# Altium schematic sheet Power_Supplies.SchDoc
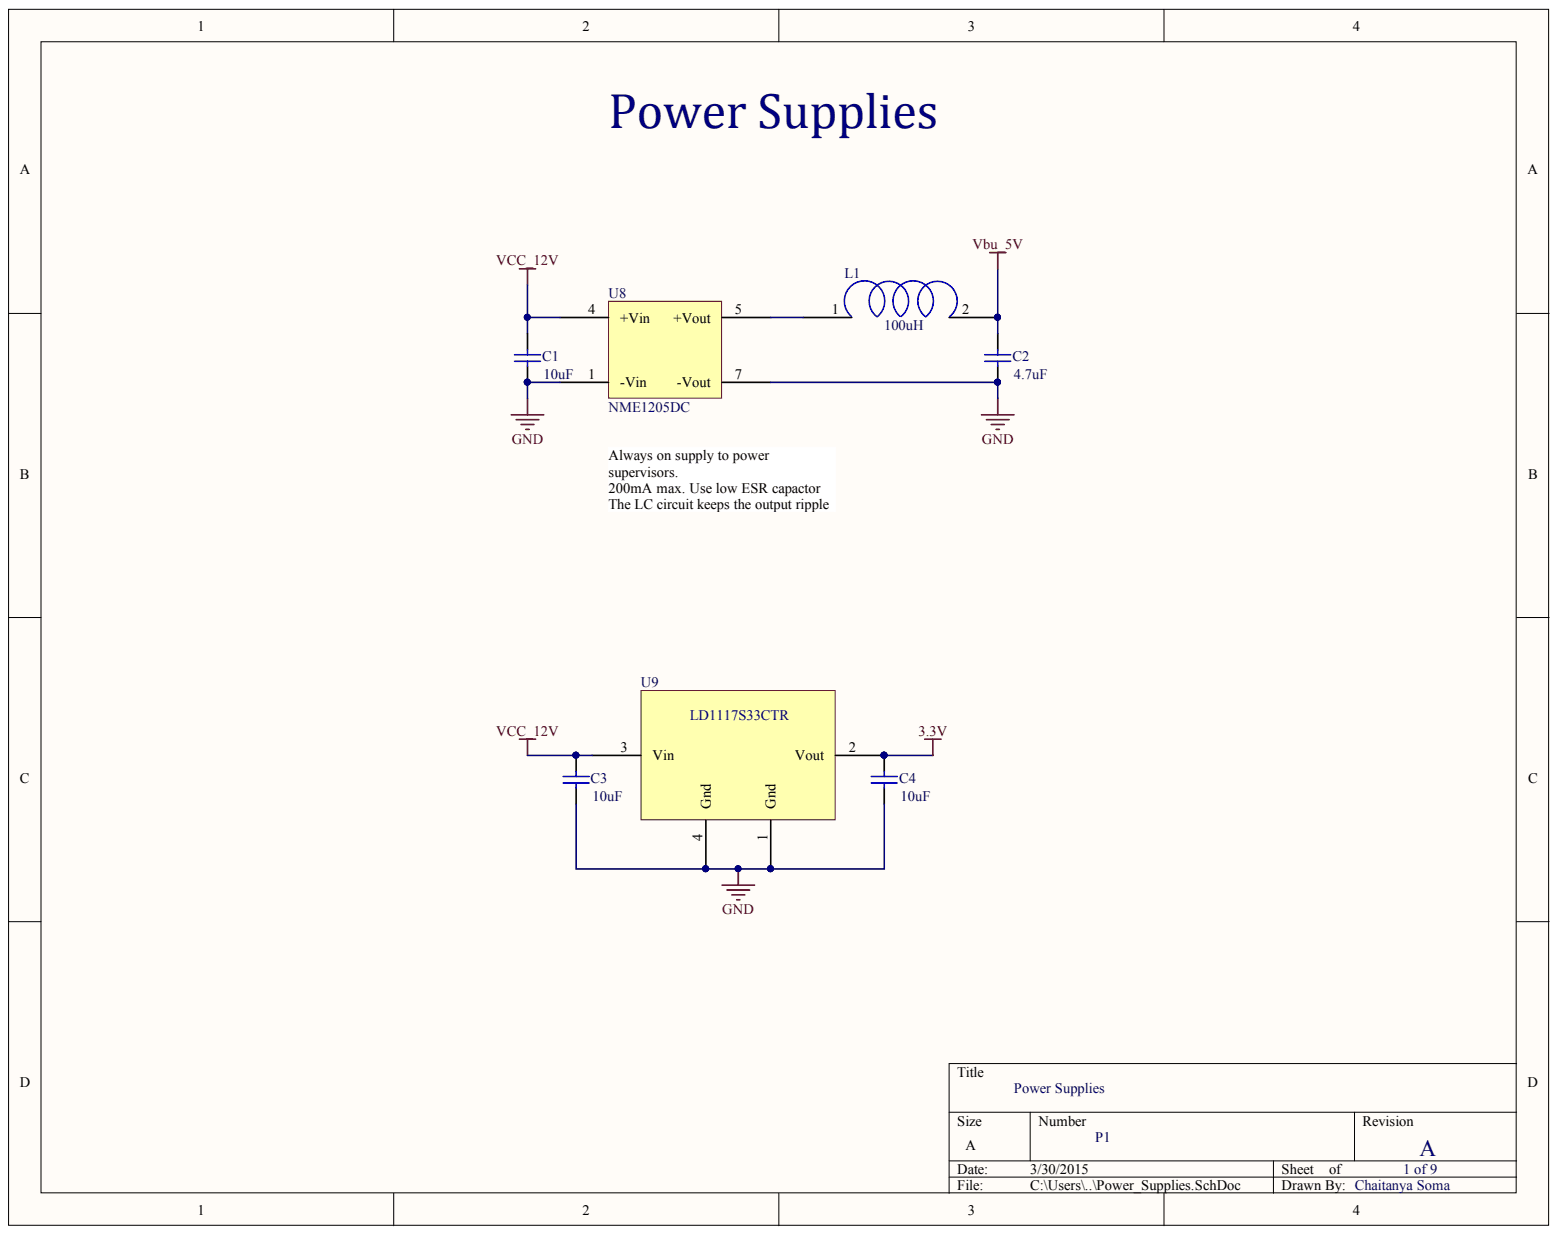
[diagram p0: Power_Supplies.SchDoc]

## Parts
C1  value 10uF  [1608[0603]]  (hidden comment: Cap Semi)
C2  value 4.7uF  [1608[0603]]  (hidden comment: Cap Semi)
C3  value 10uF  [1608[0603]]  (hidden comment: Cap Semi)
C4  value 10uF  [1608[0603]]  (hidden comment: Cap Semi)
L1  value 100uH  [811-2479-1-ND - O]  (hidden comment: 811-2479-1-ND)
U8  NME1205DC  [NME1208DC]
U9  LD1117S33CTR  [LD1117S33CTR]

## Nets
3.3V: C4.2 U9.2(Vout)
GND: C1.1 C2.1 C3.1 C4.1 U8.1(-Vin) U8.7(-Vout) U9.1(Gnd) U9.4(Gnd)
VBU_5V: C2.2 L1.2
VCC_12V: C1.2 C3.2 U8.4(+Vin) U9.3(Vin)
NetL1_1: L1.1 U8.5(+Vout)

## Library data of the parts
C1 C3 C4 = Cap Semi: Capacitor (Semiconductor SIM Model); Component Cost: 0.30000; Component Link Descriptions: CAP CER 10UF 16V 20% X5R 0603; Component Kind: Standard; Component Link URL: http://www.digikey.com/product-detail/en/GRM188R61C106MA73D/490-7201-1-ND/3900482; Digikey PN: 490-7201-1-ND; LatestRevisionDate: 29-May-2009; LatestRevisionNote: IPC-7351 Footprint Added.; Library Name: Miscellaneous Devices.IntLib; PackageDescription: Chip Capacitor; PackageReference: C1206; Published: 8-Jun-2000; Signal Integrity: Cap Semi; Simulation: CAP; Publisher: Altium Limited
C2 = Cap Semi: Capacitor (Semiconductor SIM Model); Component Cost: 0.36000; Component Link Descriptions: CAP CER 4.7UF 25V 10% X5R 0603; Component Kind: Standard; Component Link URL: http://www.digikey.com/product-detail/en/CL10A475KA8NQNC/1276-1900-1-ND/3889986; Digikey PN: 1276-1900-1-ND; LatestRevisionDate: 29-May-2009; LatestRevisionNote: IPC-7351 Footprint Added.; Library Name: Miscellaneous Devices.IntLib; PackageDescription: Chip Capacitor; PackageReference: C1206; Published: 8-Jun-2000; Signal Integrity: Cap Semi; Simulation: CAP; Publisher: Altium Limited
L1 = 811-2479-1-ND: Component Cost: 0.32000; Component Link Descriptions: FIXED IND 100UH 160MA 3.5 OHM; Component Kind: Standard; Component Link URL: http://www.digikey.com/product-detail/en/82104C/811-2479-1-ND/3178550; Digikey PN: 811-2479-1-ND; Library Name: Power.SchLib
U8 = NME1205DC: 12-5V DC-DC convertor; Component Cost: 8.12; Component Link Descriptions: Isolated DC/DC Converters 1W Single Output 12V to 5V 200mA; Component Kind: Standard; Component Link URL: http://www.mouser.com/ProductDetail/Murata-Power-Solutions/NME1205DC/?qs=FRxQVHN%2Fv%252bW3akMPrjbwhA%3D%3D; Library Name: Power.SchLib; Mouser PN: 580-NME1205DC
U9 = LD1117S33CTR: Component Cost: 0.54; Component Link Descriptions: IC REG LDO 3.3V 0.8A SOT223; Component Kind: Standard; Component Link URL: http://www.digikey.com/product-detail/en/LD1117S33CTR/497-1241-1-ND/586241; Digikey PN: 497-1241-1-ND; Library Name: Power.SchLib
